# Altium schematic sheet Logic_VHF_VER 1.1.SchDoc
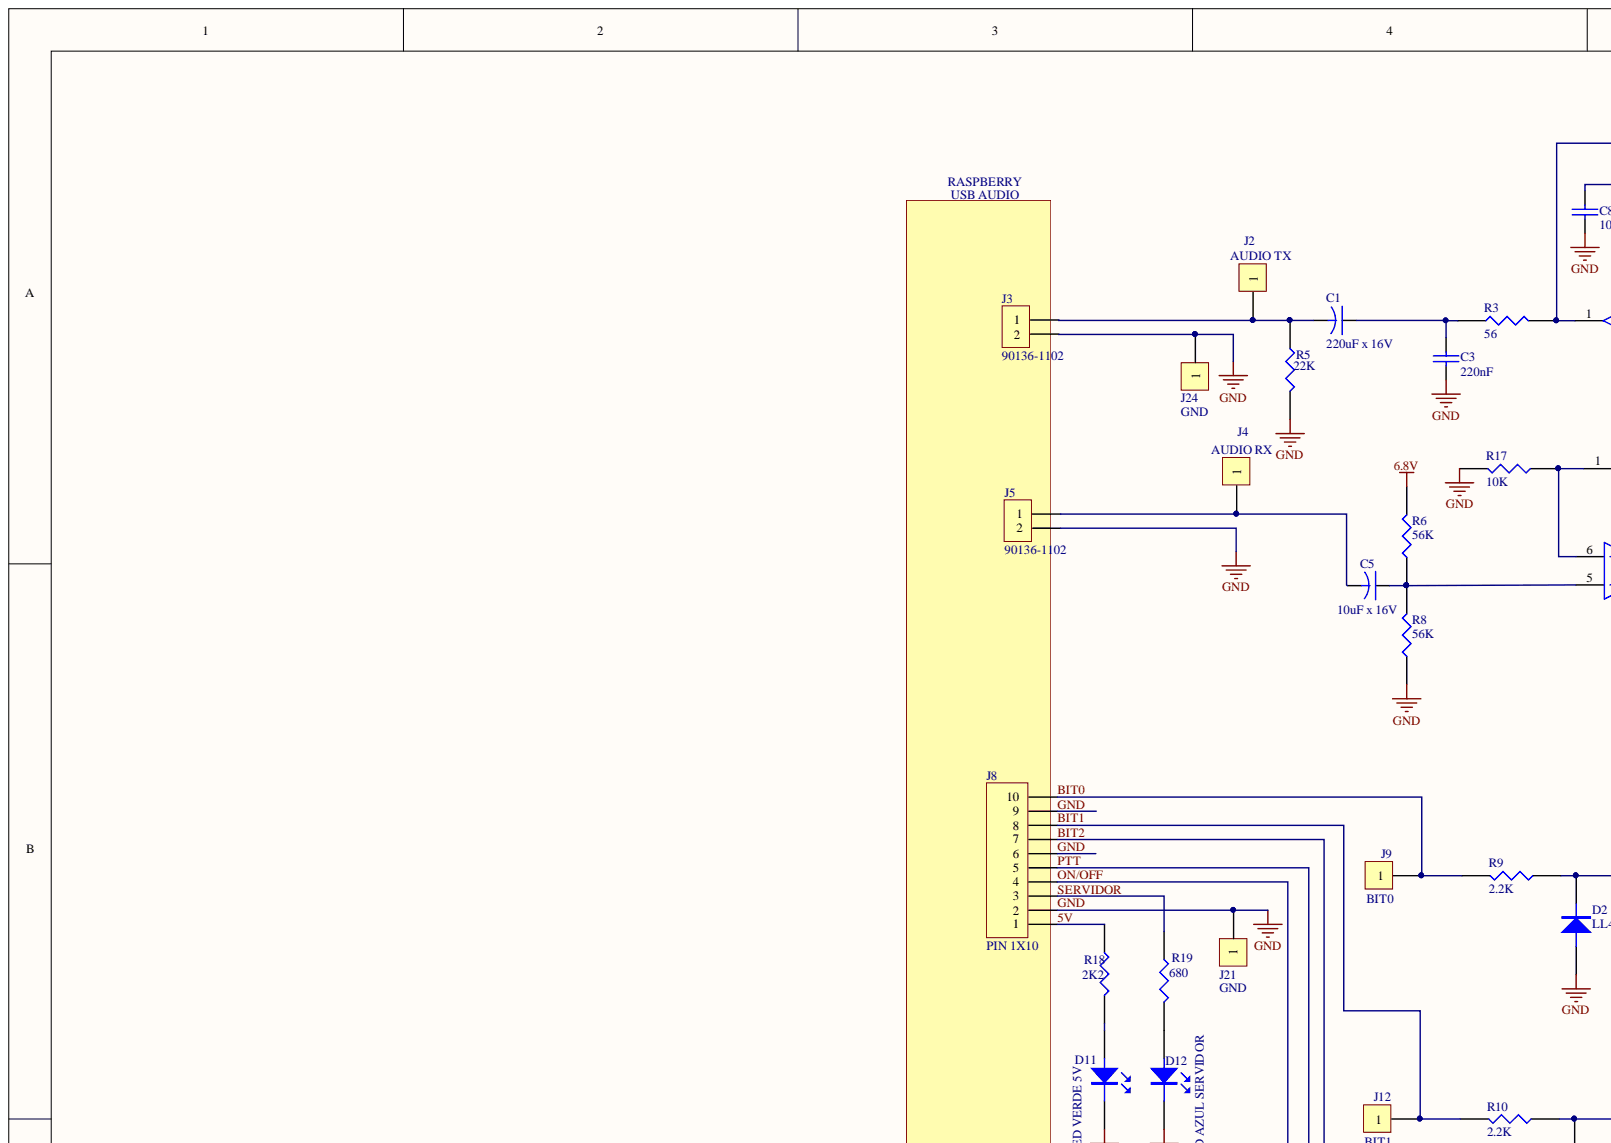
[diagram p0: Logic_VHF_VER 1.1.SchDoc, top left]
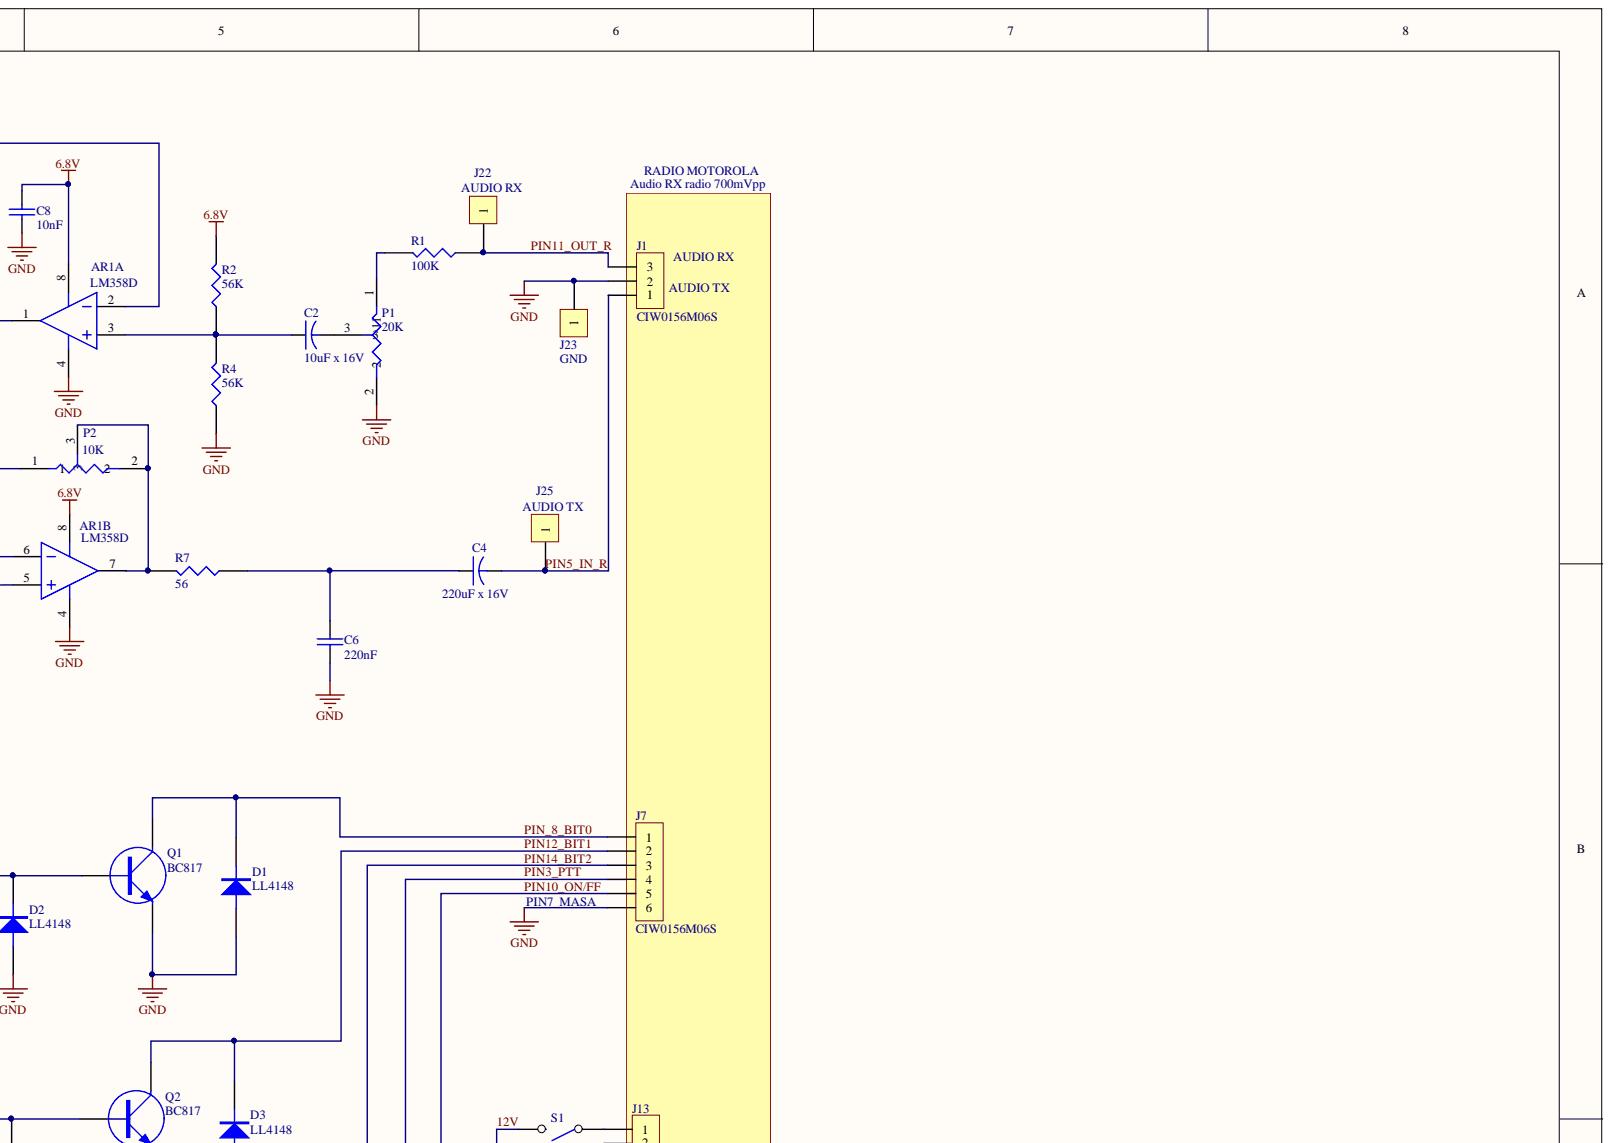
[diagram p1: Logic_VHF_VER 1.1.SchDoc, top right]
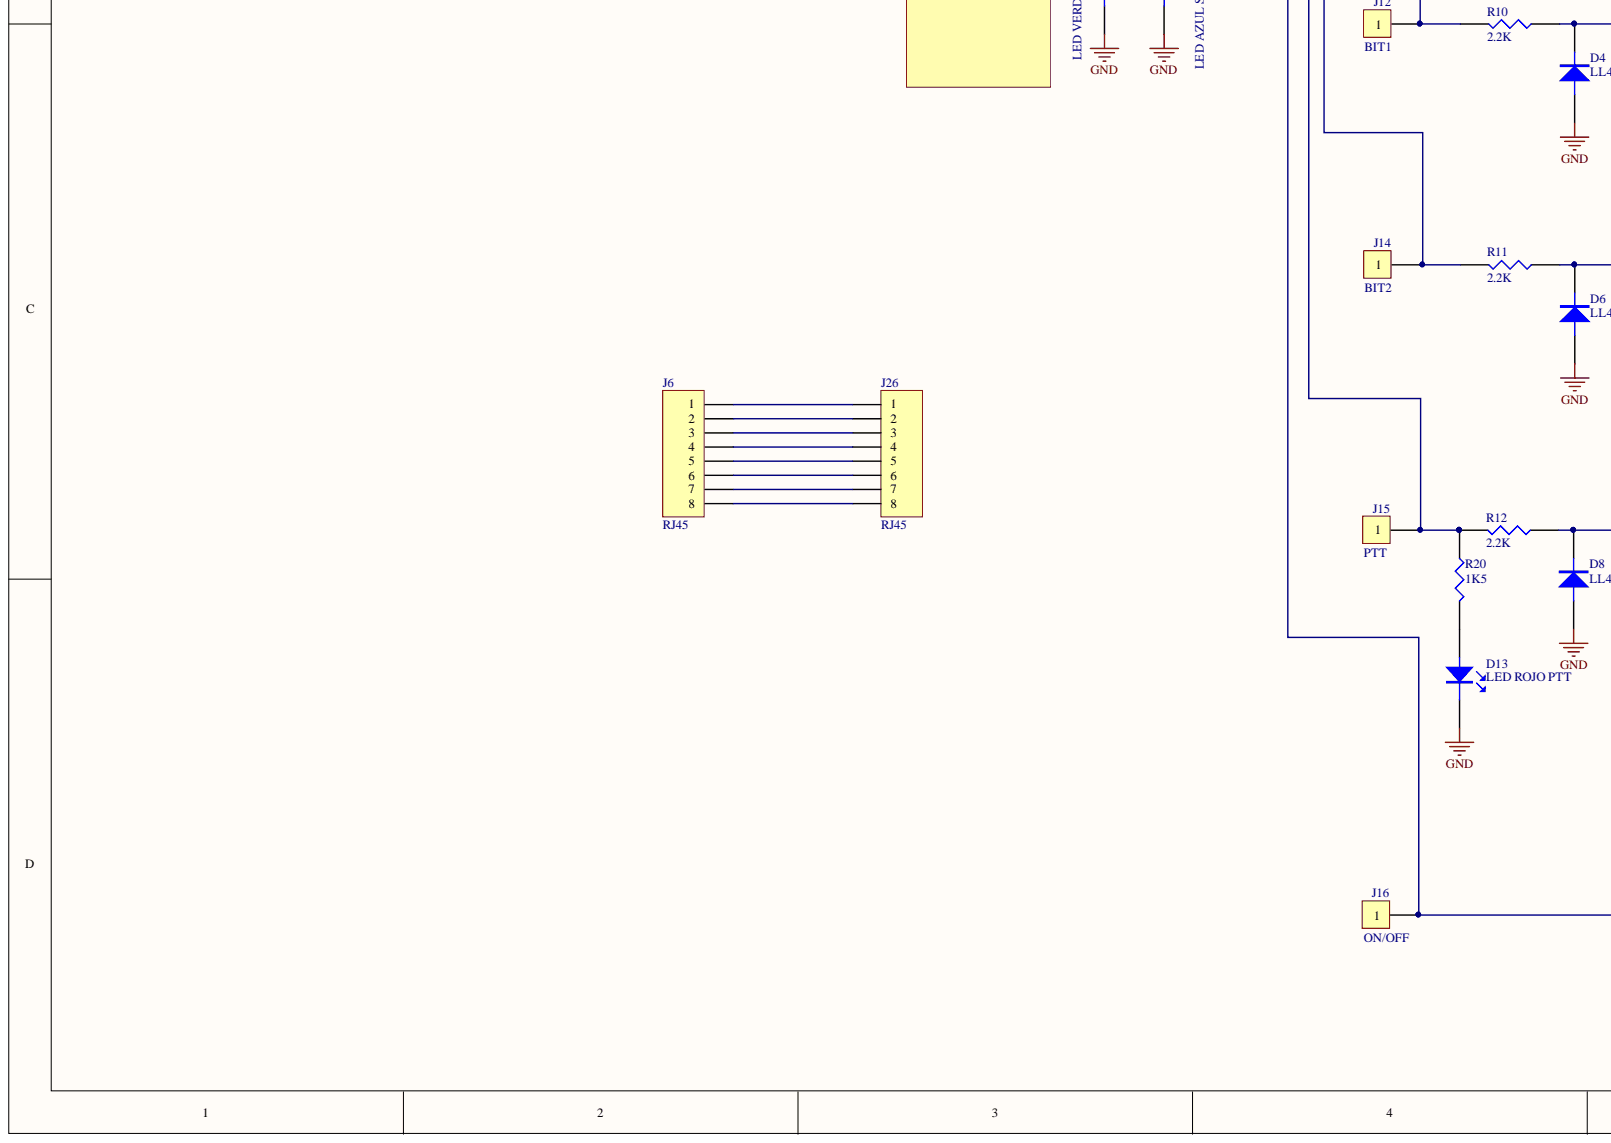
[diagram p2: Logic_VHF_VER 1.1.SchDoc, bottom left]
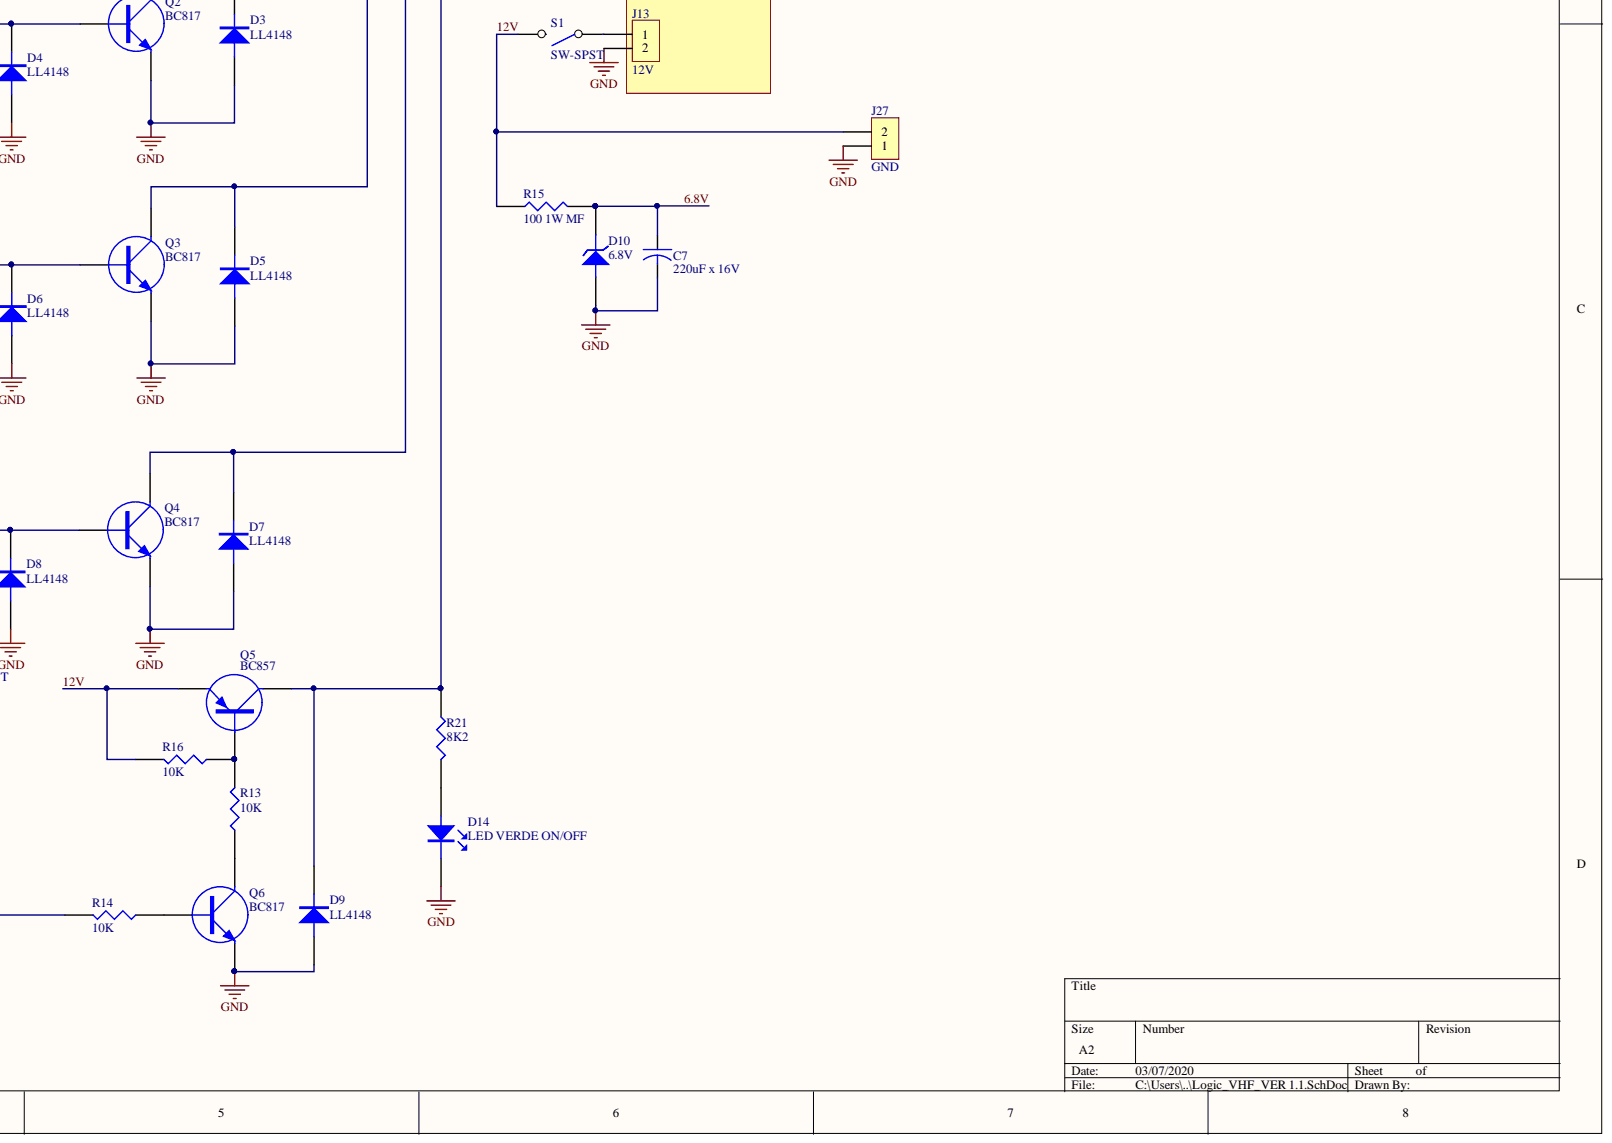
[diagram p3: Logic_VHF_VER 1.1.SchDoc, bottom right]

## Parts
AR1  LM358D  [SMD8 - 3D]  (parts A,B)
C1  220uF x 16V  [CE220_25V - 3D]
C2  10uF x 16V  [CE10_25V - 3D]
C3  220nF  [C0805 - 3D]
C4  220uF x 16V  [CE220_25V - 3D]
C5  10uF x 16V  [CE10_25V - 3D]
C6  220nF  [C0805 - 3D]
C7  220uF x 16V  [CE220_25V - 3D]
C8  10nF  [C.2 - 3D]
D1  LL4148  [DMELF - 3D - duplicate]
D2  LL4148  [DMELF - 3D - duplicate]
D3  LL4148  [DMELF - 3D - duplicate]
D4  LL4148  [DMELF - 3D - duplicate]
D5  LL4148  [DMELF - 3D - duplicate]
D6  LL4148  [DMELF - 3D - duplicate]
D7  LL4148  [DMELF - 3D - duplicate]
D8  LL4148  [DMELF - 3D - duplicate]
D9  LL4148  [DMELF - 3D - duplicate]
D10  6.8V  [DMELF - 3D - duplicate]
D11  LED VERDE 5V  [LED 3MM - 3D - VERDE]
D12  LED AZUL SERVIDOR  [LED 3MM - 3D - AMARILLO]
D13  LED ROJO PTT  [LED 3MM - 3D - ROJO]
D14  LED VERDE ON/OFF  [LED 3MM - 3D - verde]
J1  CIW0156M06S  [Header 3 paso .156 - 3D]
J2  AUDIO TX  [PIN1 - 3D]
J3  90136-1102  [90136-1102 - 3D]
J4  AUDIO RX  [PIN1 - 3D]
J5  90136-1102  [90136-1102 - 3D]
J6  RJ45  [RJ45 - 3D]
J7  CIW0156M06S  [Header 6 paso .156 - 3D]
J8  PIN 1X10  [PIN10 - 3D]
J9  BIT0  [PIN1 - 3D]
J12  BIT1  [PIN1 - 3D]
J13  12V  [MSTBVA 2,5/ 2-G-5,08]
J14  BIT2  [PIN1 - 3D]
J15  PTT  [PIN1 - 3D]
J16  ON/OFF  [PIN1 - 3D]
J21  GND  [PIN1 - 3D]
J22  AUDIO RX  [PIN1 - 3D]
J23  GND  [PIN1 - 3D]
J24  GND  [PIN1 - 3D]
J25  AUDIO TX  [PIN1 - 3D]
J26  RJ45  [RJ45 - 3D]
J27  GND  [Header 2 paso .156 - 3d]
P1  20K  [PRESET - 3D]
P2  10K  [PRESET - 3D]
Q1  BC817  [sot23 - 3d]
Q2  BC817  [sot23 - 3d]
Q3  BC817  [sot23 - 3d]
Q4  BC817  [sot23 - 3d]
Q5  BC857  [SOT23 - 3D]
Q6  BC817  [sot23 - 3d]
R1  100K  [R0805 - 3D]
R2  56K  [R300M - 3D]
R3  56  [R0805 - 3D]
R4  56K  [R300M - 3D]
R5  22K  [R0805 - 3D]
R6  56K  [R0805 - 3D]
R7  56  [R300M - 3D]
R8  56K  [R0805 - 3D]
R9  2.2K  [R300M - 3D]
R10  2.2K  [R300M - 3D]
R11  2.2K  [R300M - 3D]
R12  2.2K  [R300M - 3D]
R13  10K  [R0805 - 3D]
R14  10K  [R300M - 3D]
R15  100 1W MF  INFO: 1W MF  [R1WATTS MF HOR  - 3D]
R16  10K  [R0805 - 3D]
R17  10K  [R0805 - 3D]
R18  2K2  [R300M - 3D]
R19  680  [R300M - 3D]
R20  1K5  [R300M - 3D]
R21  8K2  [R300M - 3D]
S1  SW-SPST  [Header 2 paso .156 - 3d]

## Nets
5V: J8.1 R18.1
6.8V: AR1.8 C7.1 C8.1 D10.K R2.1 R6.1 R15.2
12V: J27.2 Q5.E R15.1 R16.2 S1.2
BIT0: J8.10 J9.1 R9.2
BIT1: J8.8 J12.1 R10.2
BIT2: J8.7 J14.1 R11.2
GND: AR1.4 C3.2 C6.2 C7.2 C8.2 D1.A D2.A D3.A D4.A D5.A D6.A D7.A D8.A D9.A D10.A D11.K D12.K D13.K D14.K J1.2 J3.2 J5.2 J7.6 J8.2 J8.6 J8.9 J13.2 J21.1 J23.1 J24.1 J27.1 P1.2 Q1.E Q2.E Q3.E Q4.E Q6.E R4.2 R5.1 R8.2 R17.1
ON/OFF: J8.4 J16.1 R14.2
PIN3_PTT: D7.K J7.4 Q4.C
PIN5_IN_R: C4.2 J1.1 J25.1
PIN10_ON/FF: D9.K J7.5 Q5.C R21.1
PIN11_OUT_R: J1.3 J22.1 R1.2
PIN12_BIT1: D3.K J7.2 Q2.C
PIN14_BIT2: D5.K J7.3 Q3.C
PIN_8_BIT0: D1.K J7.1 Q1.C
PTT: J8.5 J15.1 R12.2 R20.1
SERVIDOR: J8.3 R19.1
NetAR1_1: AR1.1 AR1.2 R3.1
NetAR1_3: AR1.3 C2.1 R2.2 R4.1
NetAR1_5: AR1.5 C5.1 R6.2 R8.1
NetAR1_6: AR1.6 P2.1 R17.2
NetAR1_7: AR1.7 P2.2 P2.3 R7.1
NetC1_1: C1.1 C3.1 R3.2
NetC1_2: C1.2 J2.1 J3.1 R5.2
NetC2_2: C2.2 P1.3
NetC4_1: C4.1 C6.1 R7.2
NetC5_2: C5.2 J4.1 J5.1
NetD2_K: D2.K Q1.B R9.1
NetD4_K: D4.K Q2.B R10.1
NetD6_K: D6.K Q3.B R11.1
NetD8_K: D8.K Q4.B R12.1
NetD11_A: D11.A R18.2
NetD12_A: D12.A R19.2
NetD13_A: D13.A R20.2
NetD14_A: D14.A R21.2
NetJ6_1: J6.1 J26.1
NetJ6_2: J6.2 J26.2
NetJ6_3: J6.3 J26.3
NetJ6_4: J6.4 J26.4
NetJ6_5: J6.5 J26.5
NetJ6_6: J6.6 J26.6
NetJ6_7: J6.7 J26.7
NetJ6_8: J6.8 J26.8
NetJ13_1: J13.1 S1.1
NetP1_1: P1.1 R1.1
NetQ5_B: Q5.B R13.2 R16.1
NetQ6_B: Q6.B R14.1
NetQ6_C: Q6.C R13.1

## Library data of the parts
AR1 = LM358: Operational Amplifier; INFO: SMD; Component Kind: Standard; Library Name: Miscellaneous Devices.lib
C1 C4 C7 = CAPACITOR: INFO: CAP 220UF 16V RADIAL; Component Kind: Standard; Description: Capacitor; Library Name: Miscellaneous Devices.lib
C2 C5 = CAPACITOR: INFO: CAP 10UF 16V RADIAL; Component Kind: Standard; Description: Capacitor; Library Name: Miscellaneous Devices.lib
C3 C6 = CAP: Capacitor; INFO: 0805; Component Kind: Standard; Library Name: Miscellaneous Devices.lib
C8 = CAP: Capacitor; INFO: CERAMICO; Component Kind: Standard; Library Name: Miscellaneous Devices.lib
D1-D9 = DIODE: INFO: DMELF; Component Kind: Standard; Description: Diode
D10 = ZENER3: Zener Diode; INFO: DMELF; Component Kind: Standard; Library Name: Miscellaneous Devices.lib
D11 D14 = LED: INFO: LED VERDE 3MM; Component Kind: Standard; Library Name: Miscellaneous Devices.lib
D12 = LED: INFO: LED AZUL 3MM; Component Kind: Standard; Library Name: Miscellaneous Devices.lib
D13 = LED: INFO: LED ROJO 3MM; Component Kind: Standard; Library Name: Miscellaneous Devices.lib
J1 = CON3: Connector; INFO: CIW0156M03S; Component Kind: Standard; Library Name: Miscellaneous Devices.lib
J2 J4 J9 J12 J14-J16 J21-J25 = CON1: Connector; INFO: CONN HEADER VERT TIN 1POS; Component Kind: Standard; Library Name: Miscellaneous Devices.lib
J3 J5 J13 = CON2: Connector; INFO: Connector Header Through Hole 2 position 0.100" (2.54mm); Component Kind: Standard; Library Name: Miscellaneous Devices.lib
J6 J26 = RJ45: RJ45 8 Pin; Component Kind: Standard; INFO: RJ45; Library Name: Miscellaneous Devices.lib
J7 = CON6: Connector; Component Kind: Standard; INFO: CIW0156M06S; Library Name: Miscellaneous Devices.lib
J8 = CON10: Connector; INFO: PIN .1 X 10; Component Kind: Standard; Library Name: Miscellaneous Devices.lib
J27 = CON2: Connector; INFO: HOLE OUT 12; Component Kind: Standard; Library Name: Miscellaneous Devices.lib
P1 P2 = RESISTOR TAPPED: INFO: PRESET HORIZONTAL; Component Kind: Standard; Library Name: Backup of Copy of Miscellaneous Devices.lib
Q1-Q4 Q6 = NPN: NPN Transistor; INFO: TRANS NPN 45V 0.5A SOT23; Component Kind: Standard; Library Name: Miscellaneous Devices.lib
Q5 = PNP: PNP Transistor; INFO: TRANS PNP 45V 0.1A SOT-23; Component Kind: Standard; Library Name: Miscellaneous Devices.lib
R1 R3 R5 R6 R8 R16-R21 = RES1: INFO: 0805; Component Kind: Standard
R2 R4 R7 R9-R12 R14 = RES1: INFO: MINI; Component Kind: Standard
R13 = RES_0805: INFO: 0805; Component Kind: Standard; Library Name: Miscellaneous Devices.lib
R15 = RES1: Component Kind: Standard
S1 = SW-SPST: INFO: HOLE SWITCH; Component Kind: Standard; Library Name: Miscellaneous Devices.lib
